# Altium schematic sheet Zhuanjie.SchDoc
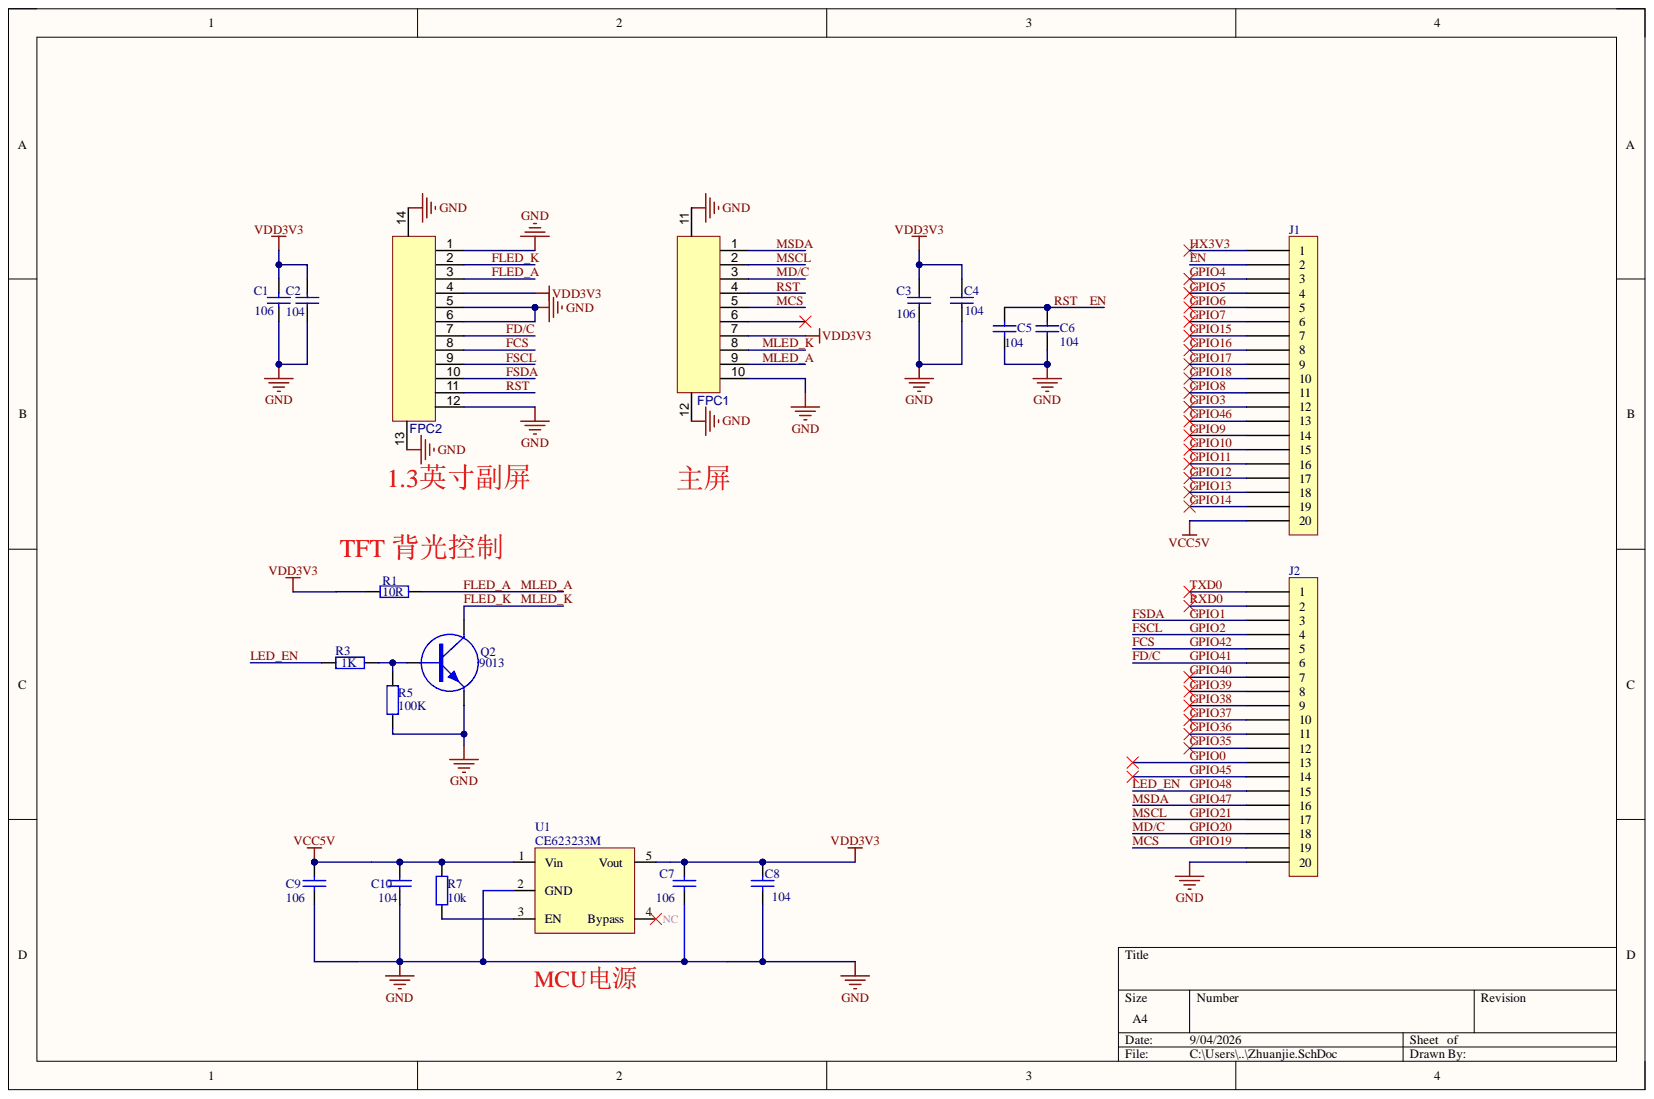
[diagram p0: Zhuanjie.SchDoc]

## Parts
C1  106  [0603C]
C2  104  [0402C]
C3  106  [0603C]
C4  104  [0402C]
C5  104  [0402C]
C6  104  [0402C]
C7  106  [0603C]
C8  104  [0402C]
C9  106  [0603C]
C10  104  [0402C]
FPC1  AFC01-S10FCA-00  [FPC-SMD_P0.5-10P_FGS-XJ-H2.0]  (value not drawn)
FPC2  AFC01-S12FCA-00  [FPC-SMD_AFC01-S12FCA-00]  (value not drawn)
J1  (no value)  [CON-1×20P-2.54]
J2  (no value)  [CON-1×20P-2.54]
Q2  9013  [SOT23-3 - BEC]
R1  10R  [0402R]
R3  1K  [0402R]
R5  100K  [0402R]
R7  10k  [0402R]
U1  CE623233M  [CE6232]

## Nets
GND: C1.1 C2.1 C3.1 C4.1 C5.1 C6.1 C7.1 C8.1 C9.1 C10.1 FPC1.10 FPC1.11 FPC1.12 FPC2.1 FPC2.5 FPC2.6 FPC2.12 FPC2.13 FPC2.14 J2.20 Q2.E R5.1 U1.2(GND)
VCC5V: C9.2 C10.2 J1.20 R7.1 U1.1(Vin)
VDD3V3: C1.2 C2.2 C3.2 C4.2 C7.2 C8.2 FPC1.7 FPC2.4 R1.2 U1.5(Vout)
EN: C5.2 C6.2 FPC1.4 FPC2.11 J1.2
FCS: FPC2.8 J2.5
FD/C: FPC2.7 J2.6
FLED_A: FPC1.9 FPC2.3 R1.1
FLED_K: FPC1.8 FPC2.2 Q2.C
FSCL: FPC2.9 J2.4
FSDA: FPC2.10 J2.3
GPIO0: J2.13
GPIO3: J1.12
GPIO4: J1.3
GPIO5: J1.4
GPIO6: J1.5
GPIO7: J1.6
GPIO8: J1.11
GPIO9: J1.14
GPIO10: J1.15
GPIO11: J1.16
GPIO12: J1.17
GPIO13: J1.18
GPIO14: J1.19
GPIO15: J1.7
GPIO16: J1.8
GPIO17: J1.9
GPIO18: J1.10
GPIO19: FPC1.5 J2.19
GPIO20: FPC1.3 J2.18
GPIO21: FPC1.2 J2.17
GPIO35: J2.12
GPIO36: J2.11
GPIO37: J2.10
GPIO38: J2.9
GPIO39: J2.8
GPIO40: J2.7
GPIO45: J2.14
GPIO46: J1.13
GPIO47: FPC1.1 J2.16
GPIO48: J2.15 R3.2
HX3V3: J1.1
RXD0: J2.2
TXD0: J2.1
NetQ2_B: Q2.B R3.1 R5.2
NetR7_2: R7.2 U1.3(EN)

Not connected: FPC1 6; U1 4(Bypass)

## Library data of the parts
C1 C3 C7 = Cap: Capacitor; Value: 105/0805; Component Kind: Standard; LatestRevisionDate: 17-Jul-2002; LatestRevisionNote: Re-released for DXP Platform.; PackageDescription: Radial Cap, Thru-Hole; 2 Leads; 0.3 in Pin Spacing; PackageReference: RAD-0.3; Published: 8-Jun-2000; Publisher: Altium Limited
C2 C4 C8 C9 = CAP: Component Kind: Standard
C5 C6 = Cap: 贴片电容; Value: 00603/104; Component Kind: Standard; LatestRevisionDate: 17-Jul-2002; LatestRevisionNote: Re-released for DXP Platform.; Library Name: MY_SCH_LIB.SchLib; PackageDescription: Radial Cap, Thru-Hole; 2 Leads; 0.3 in Pin Spacing; PackageReference: RAD-0.3; Published: 8-Jun-2000; Signal Integrity: Cap; Simulation: CAP; Publisher: Altium Limited
C10 = Cap: Capacitor; Value: 00603/104; Component Kind: Standard; LatestRevisionDate: 17-Jul-2002; LatestRevisionNote: Re-released for DXP Platform.; PackageDescription: Radial Cap, Thru-Hole; 2 Leads; 0.3 in Pin Spacing; PackageReference: RAD-0.3; Published: 8-Jun-2000; Publisher: Altium Limited
FPC1 = AFC01-S10FCA-00: 锁定特性:翻盖式;触点类型:下接;触点数量:10P;间距:0.5mm;安装方式:卧贴;; Manufacturer: JS(钜硕电子); ManufacturerPart: AFC01-S24FCC-00; AddInBom: yes; FFC, FCB Thickness: 0.3mm; Locking Feature: 翻盖式; ModelId: 978864753c34426d9d7ef60a671e5826; ModelName: FPC-SMD_P0.5-24P_FGS-XJ-H2.0; ModelTransform: 649.605,240.157,0,0,0,0,0,56.654,0; Number of Contacts: 24P; Pitch: 0.5mm; Component Kind: Standard; Contact Material: 铜合金; Contact Plating: 锡; Contact Type: 下接; ConvertToPcb: yes; Datasheet: https://item.szlcsc.com/datasheet/AFC01-S24FCC-00/253660.html; FootprintName: FPC-SMD_P0.5-24P_FGS-XJ-H2.0; Height Above Board: 2mm; JLCPCB Part Class: Extended Part; LCSC Part Name: 翻盖式 下接 P数:24P 间距:0.5mm; Library Name: MY_SCH_LIB.SchLib; Mounting Type: 卧贴; Supplier: LCSC; Supplier Footprint: SMD,P=0.5mm,卧贴; SupplierPart: C262276; Symbol: AFC01-S24FCC-00
FPC2 = AFC01-S12FCA-00: 锁定特性:翻盖式;触点类型:下接;触点数量:12P;间距:0.5mm;安装方式:卧贴;; Manufacturer: JS(钜硕电子); ManufacturerPart: AFC01-S24FCC-00; AddInBom: yes; FFC, FCB Thickness: 0.3mm; Locking Feature: 翻盖式; ModelId: 978864753c34426d9d7ef60a671e5826; ModelName: FPC-SMD_P0.5-24P_FGS-XJ-H2.0; ModelTransform: 649.605,240.157,0,0,0,0,0,56.654,0; Number of Contacts: 24P; Pitch: 0.5mm; Component Kind: Standard; Contact Material: 铜合金; Contact Plating: 锡; Contact Type: 下接; ConvertToPcb: yes; Datasheet: https://item.szlcsc.com/datasheet/AFC01-S24FCC-00/253660.html; FootprintName: FPC-SMD_P0.5-24P_FGS-XJ-H2.0; Height Above Board: 2mm; JLCPCB Part Class: Extended Part; LCSC Part Name: 翻盖式 下接 P数:24P 间距:0.5mm; Library Name: MY_SCH_LIB.SchLib; Mounting Type: 卧贴; Supplier: LCSC; Supplier Footprint: SMD,P=0.5mm,卧贴; SupplierPart: C262276; Symbol: AFC01-S24FCC-00
J1 J2 = CONNECT-20: Component Kind: Standard; Library Name: MY_SCH_LIB.SchLib
R1 R3 R5 = Res: 贴片电阻; Component Kind: Standard; Library Name: MY_SCH_LIB.SchLib
R7 = Res2: Resistor; Value: 2M/0603; Component Kind: Standard; LatestRevisionDate: 17-Jul-2002; LatestRevisionNote: Re-released for DXP Platform.; Library Name: Miscellaneous Devices.IntLib; PackageDescription: Axial Device, Thru-Hole; 2 Leads; 0.4 in Pin Spacing; PackageReference: AXIAL-0.4; Published: 8-Jun-2000; Signal Integrity: Res; Simulation: RESISTOR; Publisher: Altium Limited
Q2 = 9013: Component Kind: Standard; Library Name: mylibrary.SchLib
U1 = CE623233M: Component Kind: Standard
